# Altium schematic sheet Current_Sensors_ACS.SchDoc
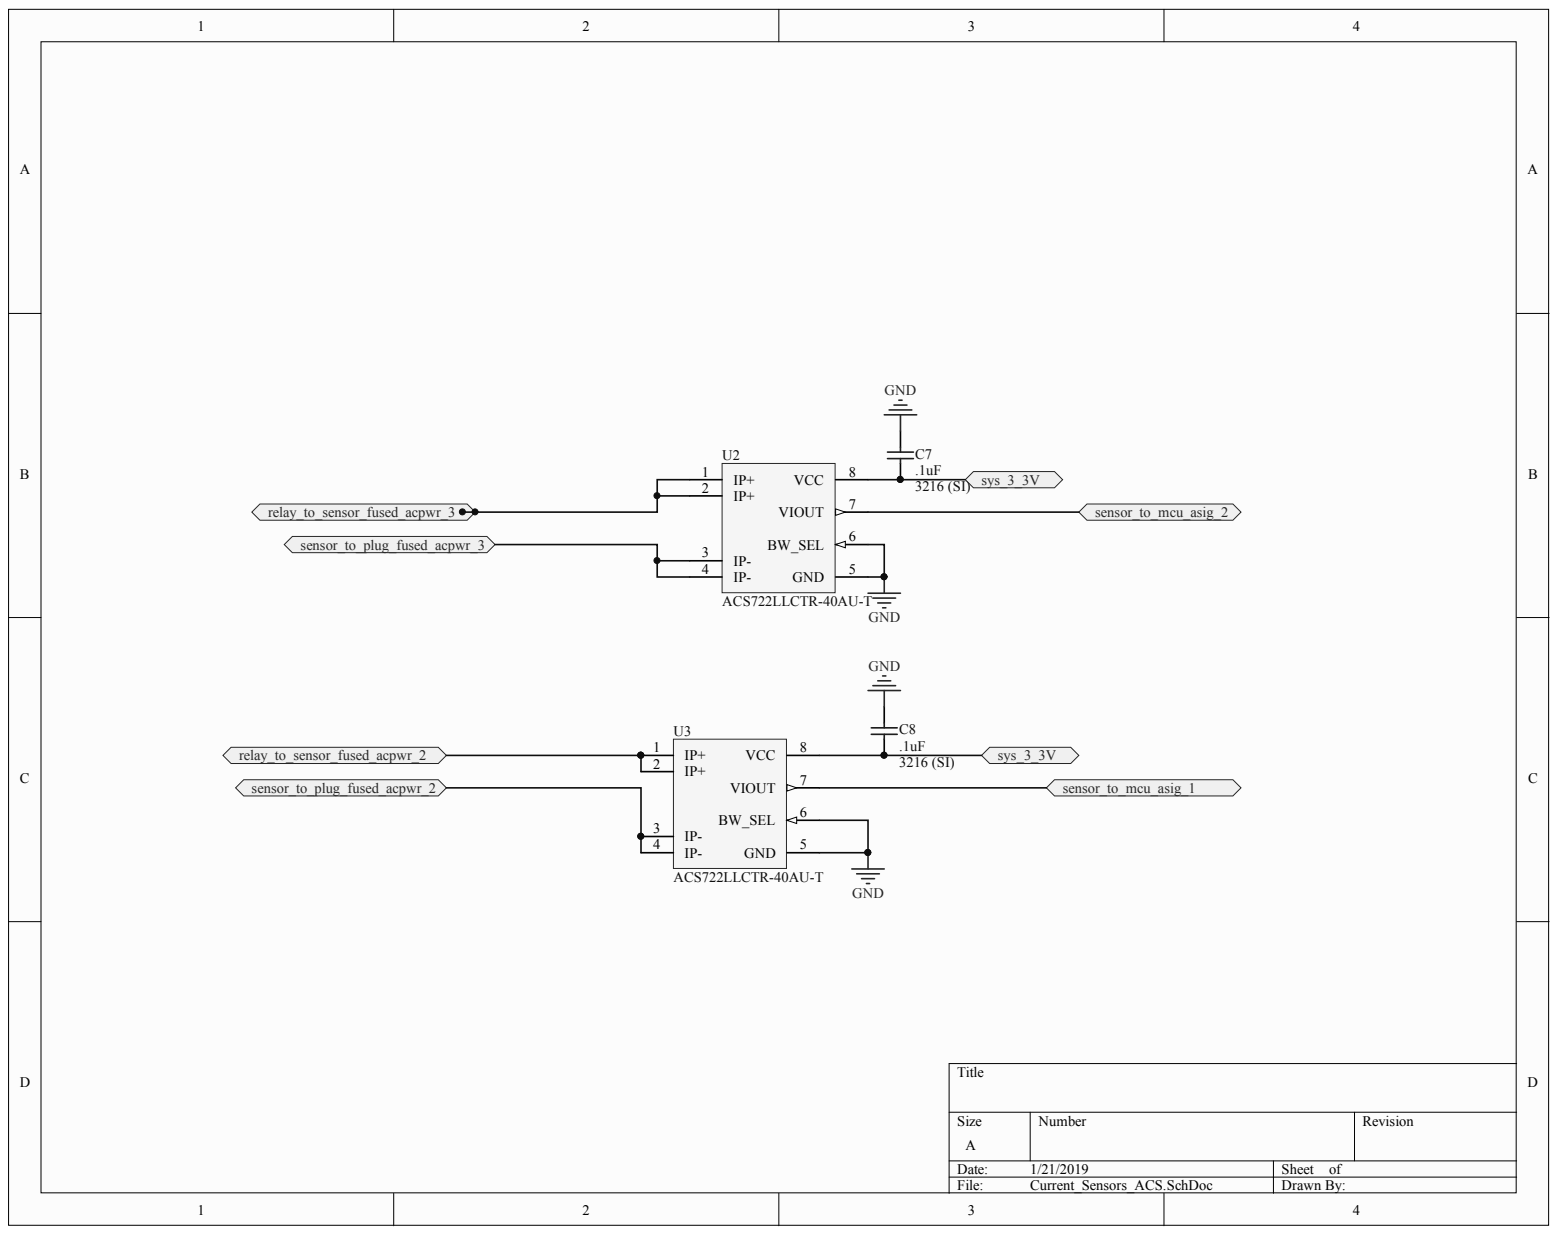
[diagram p0: Current_Sensors_ACS.SchDoc]

## Parts
C7  .1uF  [CSMD1206GRID25PADB]
C8  .1uF  [CSMD1206GRID25PADB]
U2  ACS722LLCTR-40AU-T  [TI-D8_N]
U3  ACS722LLCTR-40AU-T  [TI-D8_N]

## Ports
RELAY_TO_SENSOR_FUSED_ACPWR_2, RELAY_TO_SENSOR_FUSED_ACPWR_3, SENSOR_TO_MCU_ASIG_1, SENSOR_TO_MCU_ASIG_2, SENSOR_TO_PLUG_FUSED_ACPWR_2, SENSOR_TO_PLUG_FUSED_ACPWR_3, SYS_3_3V

## Nets
GND: C7.2 C8.2 U2.5(GND) U2.6(BW_SEL) U3.5(GND) U3.6(BW_SEL)
RELAY_TO_SENSOR_FUSED_ACPWR_2: U3.1(IP+) U3.2(IP+) ; port RELAY_TO_SENSOR_FUSED_ACPWR_2
RELAY_TO_SENSOR_FUSED_ACPWR_3: U2.1(IP+) U2.2(IP+) ; port RELAY_TO_SENSOR_FUSED_ACPWR_3
SENSOR_TO_MCU_ASIG_1: U3.7(VIOUT) ; port SENSOR_TO_MCU_ASIG_1
SENSOR_TO_MCU_ASIG_2: U2.7(VIOUT) ; port SENSOR_TO_MCU_ASIG_2
SENSOR_TO_PLUG_FUSED_ACPWR_2: U3.3(IP-) U3.4(IP-) ; port SENSOR_TO_PLUG_FUSED_ACPWR_2
SENSOR_TO_PLUG_FUSED_ACPWR_3: U2.3(IP-) U2.4(IP-) ; port SENSOR_TO_PLUG_FUSED_ACPWR_3
SYS_3_3V: C7.1 C8.1 U2.8(VCC) U3.8(VCC) ; port SYS_3_3V

## Library data of the parts
C7 C8 = CMP-150561-3: Cap Ceramic 1uF 25V X7R 20% SMD 1206 125C Plastic T/R; Lead-Free Status: R; Voltage Rating (DC): 25.0 V; Capacitance Tolerance: ±20%; Component Kind: Standard; Library Name: CommunityVault; Mounting Style: Surface Mount; OctopartID: f0ac39d8598d2f6b; Octopart Url: https://octopart.com/c1206c105m3ractu-kemet-8293447; Package/Case: 3216 (SI); Packaging: Cut Tape (CT); RoHS: Compliant
U2 U3 = CMP-19553975-3: CURRENT SENSOR, 0.009A, NSOIC-8; Quiescent Current:9mA; Bandwidth:80kHz; Sensor Case Style:NSOIC; No. of Pins:8; Supply Voltage Min:3V; Supply Voltage Max:3.6V; Operating Temperature Min:-40 C; Operat; Bandwidth: 80000.0 Hz; Component Kind: Standard; Library Name: CommunityVault; Manufacturer Part Number: ACS722LLCTR-40AU-T; OctopartID: b1eb7ab3c5db6e2c; Octopart Url: https://octopart.com/acs722llctr-40au-t-allegro+microsystems+llc-38949206; Quiescent Current: 0.009 A; RoHS: Compliant
